# Altium schematic sheet F-T-V_Converter_Schematic.SchDoc
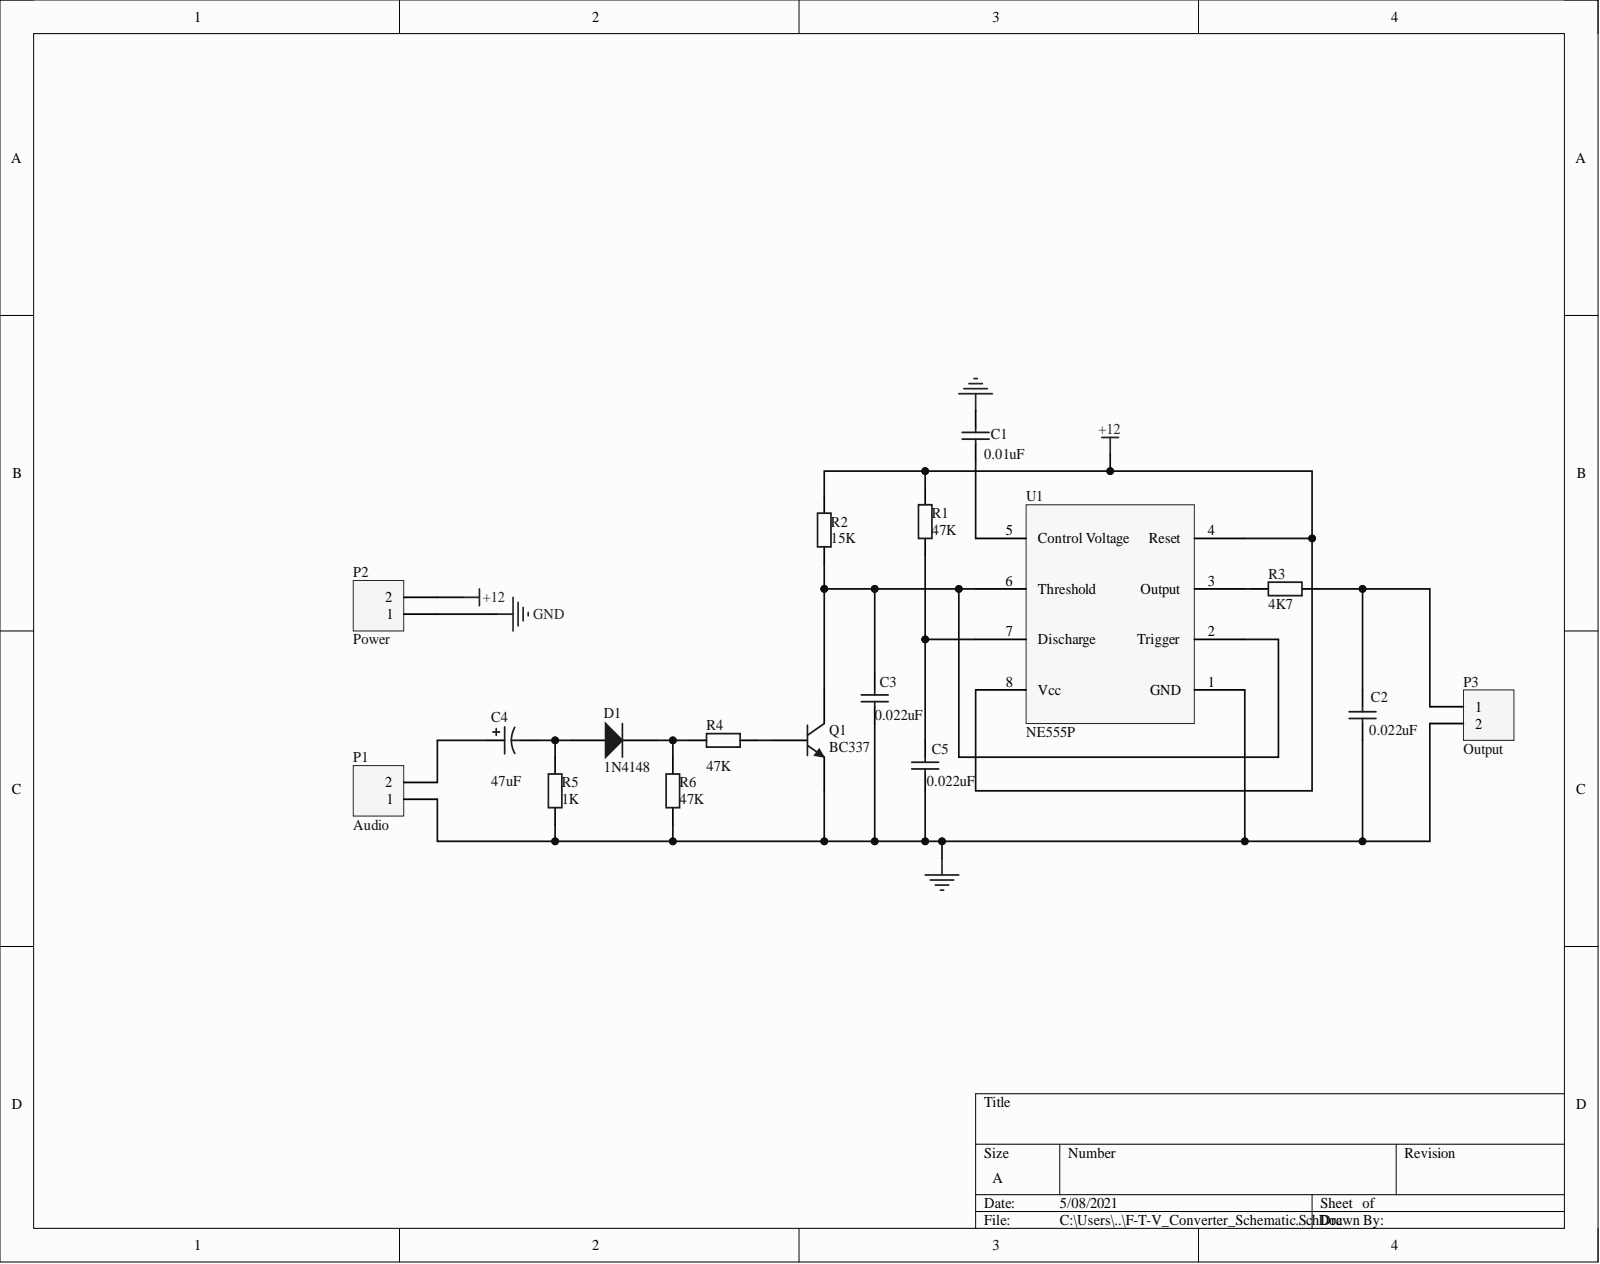
[diagram p0: F-T-V_Converter_Schematic.SchDoc]

## Parts
C1  value 0.01uF  [RAD-0.1]
C2  value 0.022uF  [RAD-0.1]
C3  value 0.022uF  [RAD-0.1]
C4  value 47uF  Capacitance: 47uF  [RB7.6-15]
C5  value 0.022uF  [RAD-0.1]
D1  1N4148  [DO-35]
P1  Audio  [HDR1X2]
P2  Power  [HDR1X2]
P3  Output  [HDR1X2]
Q1  BC337  [TO-92]
R1  value 47K  [AXIAL-0.4]  (hidden comment: Res2)
R2  value 15K  [AXIAL-0.4]  (hidden comment: Res2)
R3  4K7  [AXIAL-0.4]
R4  value 47K  [AXIAL-0.4]  (hidden comment: Res2)
R5  value 1K  [AXIAL-0.4]
R6  value 47K  [AXIAL-0.4]  (hidden comment: Res2)
U1  NE555P  [NE555]

## Nets
+12: P2.2 R1.2 R2.2 U1.4(Reset) U1.8(Vcc)
GND: C1.2 C2.1 C3.1 C5.1 P1.1 P2.1 P3.2 Q1.3 R5.1 R6.1 U1.1(GND)
NetC1_1: C1.1 U1.5(Control_Voltage)
NetC2_2: C2.2 P3.1 R3.2
NetC3_2: C3.2 Q1.1 R2.1 U1.2(Trigger) U1.6(Threshold)
NetC4_1: C4.1 P1.2
NetC4_2: C4.2 D1.1 R5.2
NetC5_2: C5.2 R1.1 U1.7(Discharge)
NetD1_2: D1.2 R4.1 R6.2
NetQ1_2: Q1.2 R4.2
NetR3_1: R3.1 U1.3(Output)

## Library data of the parts
C1 = Cap: Capacitor: ceramic; MLCC, monolithic; 10nF; 100V; X7R; ±10%; THT; Manufacturer: KEMET; Manufacturer Part Number: C315C103K1R5TA; Dissipation Factor: 2.5%; Lead Diameter: 0.51mm; Lead Length: 7mm; Lead/Base Style: Straight; Capacitance: 10nF; Case/Package: Radial; Category: Ceramic Capacitors; Component Kind: Standard; ComponentLink1Description: https://datasheet.ciiva.com/11786/0900766b80717e24-11786801.pdf?src-supplier=RSComponents; ComponentLink1URL: https://datasheet.ciiva.com/11786/0900766b80717e24-11786801.pdf?src-supplier=RSComponents; ComponentLink2Description: https://datasheet.ciiva.com/5904/f3101-goldmax-5904939.pdf?src-supplier=Farnell; ComponentLink2URL: https://datasheet.ciiva.com/5904/f3101-goldmax-5904939.pdf?src-supplier=Farnell; ComponentLink3Description: https://datasheet.ciiva.com/18102/getdatasheetpartid-77390-18102811.pdf?src-supplier=Verical; ComponentLink3URL: https://datasheet.ciiva.com/18102/getdatasheetpartid-77390-18102811.pdf?src-supplier=Verical; ComponentLink4Description: https://datasheet.ciiva.com/39122/f3101-goldmax-39122972.pdf?src-supplier=Onlinecomponents.com; ComponentLink4URL: https://datasheet.ciiva.com/39122/f3101-goldmax-39122972.pdf?src-supplier=Onlinecomponents.com; ComponentLink5Description: https://datasheet.ciiva.com/5389/f3101-goldmax-5389838.pdf?src-supplier=Mouser; ComponentLink5URL: https://datasheet.ciiva.com/5389/f3101-goldmax-5389838.pdf?src-supplier=Mouser; Construction: RADIAL; Depth: 2.54mm; Dielectric: X7R; Dielectric Material: Ceramic; Height: 2.54mm; Height - Seated (Max): 0.21inch; LatestRevisionDate: 17-Jul-2002; LatestRevisionNote: Re-released for DXP Platform.; Lead Free: Lead Free; Lead Pitch: 2.54mm; Length: 3.81mm; Library Name: Miscellaneous Devices.SchLib; Max Operating Temperature: 125°C; Min Operating Temperature: -55°C; Mount: Through Hole,PCB; Number of Pins: 2; Package Quantity: 2500; PackageDescription: Radial Cap, Thru-Hole; 2 Leads; 0.3 in Pin Spacing; PackageReference: RAD-0.3; Packaging: Bulk; Pricing 1: 1=0.26000, 10=0.17800, 50=0.11880, 100=0.10100, 500=0.07128, 1000=0.06237, 2500=0.05940, 5000=0.05494 (USD); Published: 8-Jun-2000; Radiation Hardening: No; REACH SVHC: No SVHC; RoHS: Yes; RoHS Compliant: Yes; Series: GoldMax 300 Comm X7R; Signal Integrity: Cap; Simulation: CAP; Stock 1: 32763; Supplier 1: Digi-Key; Supplier Part Number 1: 399-4147-ND; Termination: Radial; Tolerance: 10%; Voltage: 100V; Voltage Rating: 100V; Voltage Rating (DC): 100V; Width: 3.81mm; Publisher: Altium Limited
C2 C3 C5 = Cap: Cap Ceramic 0.022uF 50V Z5U 20% Radial 5.08mm 85C T/R; Manufacturer: KEMET; Manufacturer Part Number: C317C223M5U5TA7301; Capacitance: 22nF; Lead/Base Style: Formed Leads; Case/Package: Radial; Category: Ceramic Capacitors; Component Kind: Standard; ComponentLink1Description: https://datasheet.ciiva.com/32431/c052-62-mlcc-series-eng-tds-32431014.pdf?src-supplier=Distrelec; ComponentLink1URL: https://datasheet.ciiva.com/32431/c052-62-mlcc-series-eng-tds-32431014.pdf?src-supplier=Distrelec; Dielectric: Z5U; LatestRevisionDate: 17-Jul-2002; LatestRevisionNote: Re-released for DXP Platform.; Lead Pitch: 5.08mm; Length: 3.8mm; Library Name: Miscellaneous Devices.SchLib; Max Operating Temperature: 85°C; Min Operating Temperature: -10°C; Mount: Through Hole; PackageDescription: Radial Cap, Thru-Hole; 2 Leads; 0.3 in Pin Spacing; PackageReference: RAD-0.3; Packaging: Tape and Reel; Pitch: 5.08mm; Pricing 1: 1=0.37000, 10=0.25100, 50=0.16720, 100=0.14210, 500=0.10032, 1000=0.08778 (USD); Pricing 2: 1=0.82000, 10=0.57700, 50=0.43640, 100=0.38020, 500=0.28160, 1000=0.23936 (USD); Published: 8-Jun-2000; Radiation Hardening: No; RoHS: Yes; RoHS Compliant: Yes; Series: GoldMax 300 Comm Z5U; Signal Integrity: Cap; Simulation: CAP; Stock 1: 5732; Stock 2: 1856; Supplier 1: Digi-Key; Supplier 2: Digi-Key; Supplier Part Number 1: 399-14073-1-ND; Supplier Part Number 2: 445-175388-1-ND; Termination: Radial; Tolerance: 20%; Voltage Rating: 50V; Width: 2.54mm; Publisher: Altium Limited
C4 = Cap Pol1: Cap Aluminum 47uF 16V 20% Radial Aluminum Cylindrical Can 2mm 155mA 5000 hr 105°C Ammo Pack; Manufacturer: Nichicon; Manufacturer Part Number: UPV1C470MFD1TD; Impedance: 1.4R; Life (Hours): 5000Hour; Case/Package: Radial; Category: Aluminum Electrolytic Capacitors; Component Kind: Standard; ComponentLink1Description: https://datasheet.ciiva.com/29544/e-pv-29544776.pdf?src-supplier=Digi-Key; ComponentLink1URL: https://datasheet.ciiva.com/29544/e-pv-29544776.pdf?src-supplier=Digi-Key; ComponentLink2Description: https://datasheet.ciiva.com/29544/e-pv-29544777.pdf?src-supplier=Digi-Key; ComponentLink2URL: https://datasheet.ciiva.com/29544/e-pv-29544777.pdf?src-supplier=Digi-Key; Height - Seated (Max): 0.492inch; LatestRevisionDate: 17-Jul-2002; LatestRevisionNote: Re-released for DXP Platform.; Lead Pitch: 0.079inch; Library Name: Miscellaneous Devices.SchLib; Max Operating Temperature: 105°C; Min Operating Temperature: -55°C; Mount: Through Hole; Package Quantity: 2000; PackageDescription: Polarized Capacitor (Radial); 2 Leads; PackageReference: RB7.6-15; Packaging: Tape and Reel; Pricing 1: 1=0.47000, 10=0.32800, 50=0.22980, 100=0.19700, 500=0.14776, 1000=0.12477 (USD); Published: 8-Jun-2000; Ripple Current: 155mA; RoHS: Yes; RoHS Compliant: Yes; Signal Integrity: Cap Pol; Simulation: CAP; Stock 1: 1934; Supplier 1: Digi-Key; Supplier Part Number 1: 493-11656-1-ND; Tolerance: 20%; Voltage Rating: 16V; Publisher: Altium Limited
D1 = Diode 1N4148: High Conductance Fast Diode; Code_JEDEC: DO-204-AH; Component Kind: Standard; ComponentLink1Description: Manufacturer Link; ComponentLink1URL: http://www.fairchildsemi.com/; ComponentLink2Description: Datasheet; ComponentLink2URL: http://www.fairchildsemi.com/ds/1N%2F1N4148.pdf; DatasheetVersion: 1997; LatestRevisionDate: 17-Jul-2002; LatestRevisionNote: Re-released for DXP Platform.; Library Name: Miscellaneous Devices.SchLib; PackageDescription: Diode, Axial; Body 3.8 x 1.9 mm (LxDia. max), Lead Dia. 0.53 mm (max); PackageReference: DO-35; Published: 8-Jun-2000; Signal Integrity: 1N4148; Simulation: 1N4148; Publisher: Altium Limited
P1-P3 = Header 2: Header, 2-Pin; Component Kind: Standard; LatestRevisionDate: 17-Jul-2002; LatestRevisionNote: Re-released for DXP Platform.; Library Name: Miscellaneous Connectors.SchLib; Signal Integrity: Connector; Publisher: Altium Limited
Q1 = NPN: NPN Bipolar Transistor; Code_JEDEC: TO-226-AA; Component Kind: Standard; LatestRevisionDate: 17-Jul-2002; LatestRevisionNote: Re-released for DXP Platform.; Library Name: Miscellaneous Devices.SchLib; PackageDescription: TO, Flat Index; 3 In-Line, Axial Leads; Body Dia. 4.8mm; Leads 0.55 x 0.51 mm (max); PackageReference: TO-226-AA; Published: 8-Jun-2000; Signal Integrity: NPN; Simulation: NPN; Publisher: Altium Limited
R1 R2 R4-R6 = Res2: Resistor; Component Kind: Standard; LatestRevisionDate: 17-Jul-2002; LatestRevisionNote: Re-released for DXP Platform.; Library Name: Miscellaneous Devices.SchLib; PackageDescription: Axial Device, Thru-Hole; 2 Leads; 0.4 in Pin Spacing; PackageReference: AXIAL-0.4; Published: 8-Jun-2000; Signal Integrity: Res; Simulation: RESISTOR; Publisher: Altium Limited
R3 = Res2: RES 4.7K OHM 1/4W 5% AXIAL; Manufacturer: Stackpole Electronics; Manufacturer Part Number: CF14JT47K0; Max Power Dissipation: 250mW; Resistance: 47kR; Temperature Coefficient: 0ppm/°C; Working Voltage: 350V; Case/Package: Conformal; Category: Through-Hole Resistors; Component Kind: Standard; ComponentLink1Description: https://www.verical.com/server-webapp/html/getDatasheet?partID=364248&src-supplier=Verical; ComponentLink1URL: https://www.verical.com/server-webapp/html/getDatasheet?partID=364248&src-supplier=Verical; ComponentLink2Description: https://www.seielect.com/catalog/SEI-CF_CFM.pdf?src-supplier=Avnet; ComponentLink2URL: https://www.seielect.com/catalog/SEI-CF_CFM.pdf?src-supplier=Avnet; ComponentLink3Description: http://www.seielect.com/Catalog/SEI-CF_CFM.pdf?src-supplier=Future+Electronics; ComponentLink3URL: http://www.seielect.com/Catalog/SEI-CF_CFM.pdf?src-supplier=Future+Electronics; ComponentLink4Description: https://www.seielect.com/catalog/SEI-Packaging.pdf?src-supplier=Digi-Key; ComponentLink4URL: https://www.seielect.com/catalog/SEI-Packaging.pdf?src-supplier=Digi-Key; ComponentLink5Description: http://components.arrow.com/part/dataview/27620275S9848655N1174?src-supplier=Arrow+Electronics; ComponentLink5URL: http://components.arrow.com/part/dataview/27620275S9848655N1174?src-supplier=Arrow+Electronics; ComponentLink6Description: https://datasheet.ciiva.com/15215/getdatasheetpartid-360405-15215963.pdf?src-supplier=Verical; ComponentLink6URL: https://datasheet.ciiva.com/15215/getdatasheetpartid-360405-15215963.pdf?src-supplier=Verical; Construction: Cylindrical; Contact Plating: Tin,Matte; Diameter: 2.3mm; Features: Flame Retardant Coating; LatestRevisionDate: 17-Jul-2002; LatestRevisionNote: Re-released for DXP Platform.; Lead Free: Lead Free; Length: 6.5mm; Library Name: Miscellaneous Devices.SchLib; Max Operating Temperature: 155°C; Military Standard: Not; Min Operating Temperature: -55°C; Mount: Through Hole,Axial; Number of Terminations: 2; Package Quantity: 1; PackageDescription: Axial Device, Thru-Hole; 2 Leads; 0.4 in Pin Spacing; PackageReference: AXIAL-0.4; Packaging: Tape and Reel; Power Rating: 250mW; Pricing 1: 1=0.10000, 10=0.03600, 25=0.02640, 50=0.02000, 100=0.01480, 250=0.01124, 500=0.00900, 1000=0.00662 (USD); Pricing 2: 1=0.10000, 10=0.03600, 25=0.02640, 50=0.02000, 100=0.01480, 250=0.01124, 500=0.00900, 1000=0.00662 (USD); Published: 8-Jun-2000; Radiation Hardening: No; Resistor Type: Carbon Film; RoHS: Yes; RoHS Compliant: Yes; Series: CF; Signal Integrity: Res; Simulation: RESISTOR; Stock 1: 174171; Stock 2: 247552; Supplier 1: Digi-Key; Supplier 2: Digi-Key; Supplier Part Number 1: CF14JT47K0CT-ND; Supplier Part Number 2: CF14JT4K70CT-ND; Technology: CARBON FILM; Termination: Axial; Tolerance: 5%; Value: 4K7; Publisher: Altium Limited
U1 = NE555P: Standard Timer Single 8-Pin PDIP Tube; Manufacturer: Texas Instruments; Current Rating: 10mA; Frequency: 500kHz; High Level Output Current: -200mA; Low Level Output Current: 200mA; Max Supply Voltage: 16V; Min Supply Voltage: 4.5V; Nominal Supply Current: 2mA; Number of Timers/Counters: 1; Operating Supply Voltage: 5V; Quiescent Current: 15mA; Case/Package: PDIP; Category: Clock and Timing; Component Kind: Standard; ComponentLink1Description: https://datasheet.ciiva.com/1139/sa555-1139904.pdf?src-supplier=Element14; ComponentLink1URL: https://datasheet.ciiva.com/1139/sa555-1139904.pdf?src-supplier=Element14; ComponentLink2Description: https://datasheet.ciiva.com/19457/904085-19457361.pdf?src-supplier=Jameco; ComponentLink2URL: https://datasheet.ciiva.com/19457/904085-19457361.pdf?src-supplier=Jameco; ComponentLink3Description: https://datasheet.ciiva.com/26325/152184-da-01-en-ne555p-timer-tid-26325915.pdf?src-supplier=Conrad; ComponentLink3URL: https://datasheet.ciiva.com/26325/152184-da-01-en-ne555p-timer-tid-26325915.pdf?src-supplier=Conrad; ComponentLink4Description: https://datasheet.ciiva.com/1147/1764032-1147750.pdf?src-supplier=Newark; ComponentLink4URL: https://datasheet.ciiva.com/1147/1764032-1147750.pdf?src-supplier=Newark; ComponentLink5Description: https://datasheet.ciiva.com/14094/ne555-series-eng-tds-14094950.pdf?src-supplier=Distrelec; ComponentLink5URL: https://datasheet.ciiva.com/14094/ne555-series-eng-tds-14094950.pdf?src-supplier=Distrelec; ComponentLink6Description: https://datasheet.ciiva.com/14274/ne555-14274456.pdf?src-supplier=Avnet; ComponentLink6URL: https://datasheet.ciiva.com/14274/ne555-14274456.pdf?src-supplier=Avnet; ComponentLink7Description: https://datasheet.ciiva.com/15152/getdatasheetpartid-248510-15152620.pdf?src-supplier=Verical; ComponentLink7URL: https://datasheet.ciiva.com/15152/getdatasheetpartid-248510-15152620.pdf?src-supplier=Verical; ComponentLink8Description: https://datasheet.ciiva.com/1233/ne555-1233454.pdf?src-supplier=Digi-Key; ComponentLink8URL: https://datasheet.ciiva.com/1233/ne555-1233454.pdf?src-supplier=Digi-Key; ComponentLink9Description: https://datasheet.ciiva.com/26228/ne555-26228892.pdf?src-supplier=Avnet+Europe; ComponentLink9URL: https://datasheet.ciiva.com/26228/ne555-26228892.pdf?src-supplier=Avnet+Europe; Contact Plating: Gold; Height: 4.57mm; Lead Free: Lead Free; Length: 9.81mm; Library Name: IC_Timers.SchLib; Manufacturer Part Number: NE555P; Max Operating Temperature: 70°C; Min Operating Temperature: 0°C; Mount: Through Hole; Number of Pins: 8; Operating Supply Current: 10mA; Package Quantity: 50; Packaging: Rail/Tube; Pricing 1: 1=0.37000, 10=0.29900, 50=0.29900, 100=0.20300, 1000=0.11400, 10000=0.09800 (USD); Radiation Hardening: No; REACH SVHC: No SVHC; RoHS: Yes; RoHS Compliant: Yes; Stock 1: 1667; Supplier 1: Mouser; Supplier Part Number 1: 595-NE555P; Weight: 0.015535oz; Width: 6.35mm
